# Altium schematic sheet [09] UI.SchDoc("[09] UI")
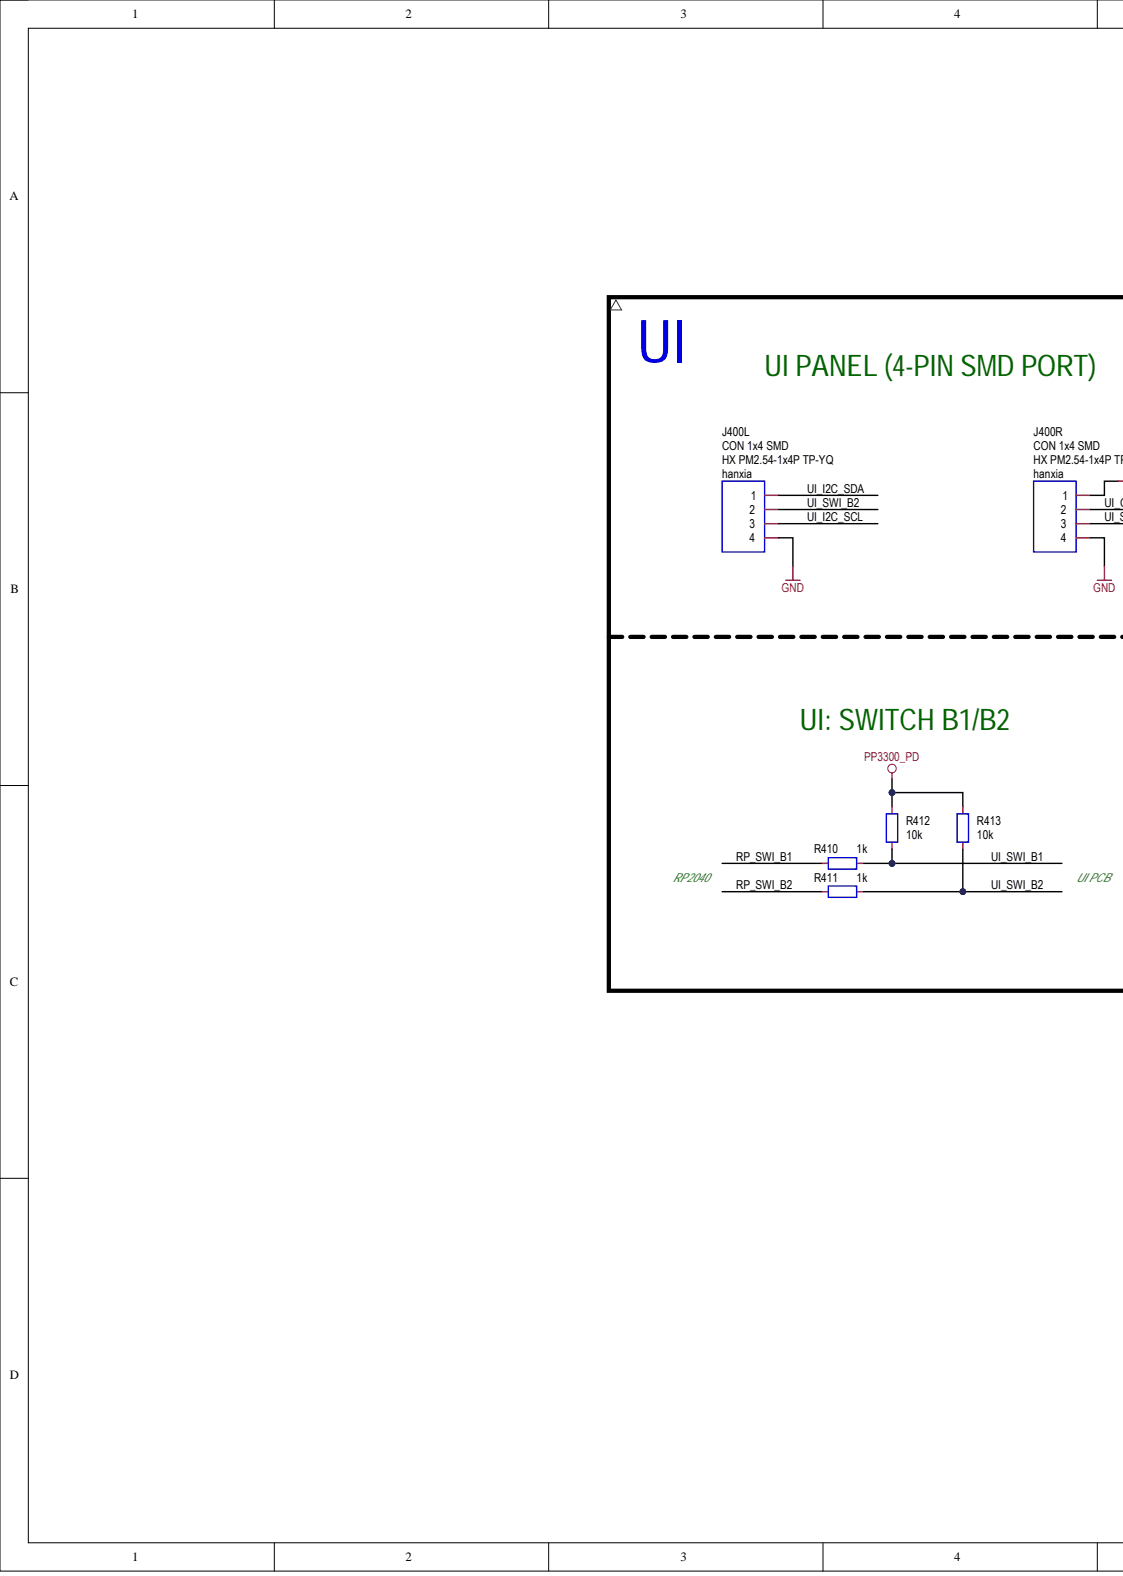
[diagram p0: [09] UI.SchDoc, left]
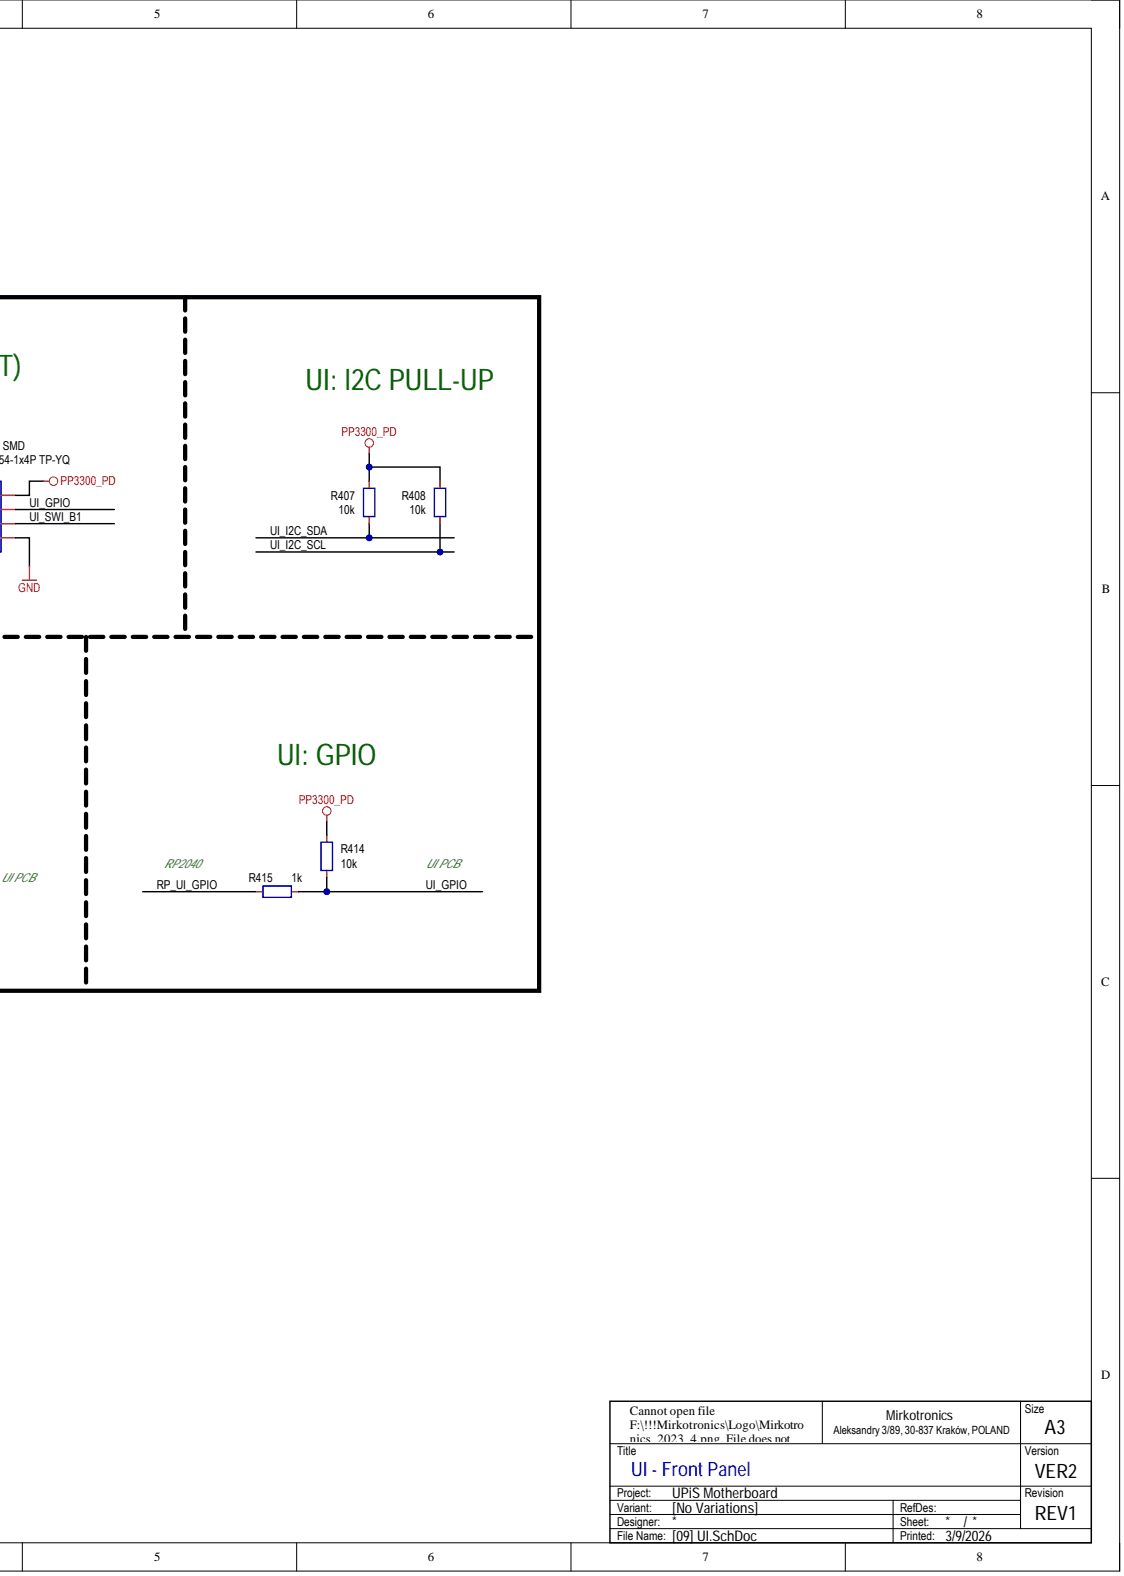
[diagram p1: [09] UI.SchDoc, right]

## Parts
J400L  CON 1x4 SMD  Manufacturer: hanxia  Mounting Type: SMD  MPN: HX PM2.54-1x4P TP-YQ
J400R  CON 1x4 SMD  Manufacturer: hanxia  Mounting Type: SMD  MPN: HX PM2.54-1x4P TP-YQ
R407  10k
R408  10k
R410  1k
R411  1k
R412  10k
R413  10k
R414  10k
R415  1k

## Nets
GND: J400L.4 J400R.4
PP3300_PD: J400R.1 R407.2 R408.2 R412.2 R413.2 R414.2
RP_SWI_B1: R410.2
RP_SWI_B2: R411.2
RP_UI_GPIO: R415.2
UI_GPIO: J400R.2 R414.1 R415.1
UI_I2C_SCL: J400L.3 R408.1
UI_I2C_SDA: J400L.1 R407.1
UI_SWI_B1: J400R.3 R410.1 R412.1
UI_SWI_B2: J400L.2 R411.1 R413.1

## Library data of the parts
J400L J400R = CON_1X04_COL: Connector; 1 Row;; Component Subtype: Pin header; Package (Case): SMD 2.54mm; Component Kind: Standard; Component Type: Connector; Supplier 1: LCSC; Supplier 2: Mouser; Supplier 3: DigiKey; Supplier Part Number 1: C42379197; Value: CON 1x4 SMD; Library Name: MirFol_lib.SchLib
R407 R408 R412-R414 = RES-0004: Resistor; Thick Film; 10k; 1%; 62.5mW; 100PPM; 50V; Standard; SMD; 0402 (1005);; Manufacturer: Yageo Corporation; MPN: RC0402FR-0710KL; Component Body: 1.00 x 0.50mm; Database Table Name: RESISTOR$; Packaging Type: Tape & Reel; Component Height: 0.35mm; Component Kind: Standard; Component Subtype: Thick Film; Component Type: Resistor; Features: Standard; ID: RES-0004; Mounting Type: SMD; Operating Temperature: -55°C to +155°C; Package (Case): 0402 (1005); Power Rating: 62.5mW; Resistance: 10k; ROHS Status: RoHS Compliant; Supplier 1: LCSC; Supplier 2: Farnell; Supplier 3: Mouser; Supplier Part Number 1: C60490; Supplier Part Number 2: 9239359; Supplier Part Number 3: 603-RC0402FR-0710KL; TCR: 100PPM; Tolerance: 1%; Value: 10k; Voltage Rating: 50V; Library Name: MirFol_db_local.DbLib
R410 R411 R415 = RES-0003: Resistor; Thick Film; 1k; 1%; 62.5mW; 100PPM; 50V; Standard; SMD; 0402 (1005);; Manufacturer: Yageo Corporation; MPN: RC0402FR-071KL; Component Body: 1.00 x 0.50mm; Database Table Name: RESISTOR$; Packaging Type: Tape & Reel; Component Height: 0.35mm; Component Kind: Standard; Component Subtype: Thick Film; Component Type: Resistor; Features: Standard; ID: RES-0003; Mounting Type: SMD; Operating Temperature: -55°C to +155°C; Package (Case): 0402 (1005); Power Rating: 62.5mW; Resistance: 1k; ROHS Status: RoHS Compliant; Supplier 1: LCSC; Supplier 2: Farnell; Supplier 3: Mouser; Supplier Part Number 1: C106235; Supplier Part Number 2: 9239235; Supplier Part Number 3: 603-RC0402FR-071KL; TCR: 100PPM; Tolerance: 1%; Value: 1k; Voltage Rating: 50V; Library Name: MirFol_db_local.DbLib
